# Altium schematic sheet YomraGMPCB.SchDoc (continued)

## Library data of the parts
BZ1 = BUZZER 12mm: Component Kind: Standard; Description: YX-YT12075-YT03D; Library Name: diodes.IntLib
C1 C3-C5 C7 C8 C12 C14 C16 C17 C19-C21 C23 C24 C26 = CAP 1206 100nF 50VDC ±10% X7R: Cap 100nF 50V 1206 SMD 10% X7R; Manufacturer: Yageo; Material: Ceramic; Insulation Resistance: 100GR; Case Code (Metric): 3216; Case Code (Imperial): 1206; Case/Package: 1206; Category: Ceramic Capacitors; Component Kind: Standard; ComponentLink1Description: https://datasheet.ciiva.com/11786/0900766b80d84f1d-11786968.pdf?src-supplier=RSComponents; ComponentLink1URL: https://datasheet.ciiva.com/11786/0900766b80d84f1d-11786968.pdf?src-supplier=RSComponents; ComponentLink2Description: https://datasheet.ciiva.com/7978/1489800-7978230.pdf?src-supplier=Element14; ComponentLink2URL: https://datasheet.ciiva.com/7978/1489800-7978230.pdf?src-supplier=Element14; ComponentLink3Description: https://datasheet.ciiva.com/28450/1489800-28450892.pdf?src-supplier=Element14; ComponentLink3URL: https://datasheet.ciiva.com/28450/1489800-28450892.pdf?src-supplier=Element14; ComponentLink4Description: https://datasheet.ciiva.com/144/mlccorderingcode-144906.pdf?src-supplier=Digi-Key; ComponentLink4URL: https://datasheet.ciiva.com/144/mlccorderingcode-144906.pdf?src-supplier=Digi-Key; ComponentLink5Description: https://datasheet.ciiva.com/144/mlccorderingcode-144905.pdf?src-supplier=Digi-Key; ComponentLink5URL: https://datasheet.ciiva.com/144/mlccorderingcode-144905.pdf?src-supplier=Digi-Key; ComponentLink6Description: https://datasheet.ciiva.com/144/mlccorderingcode-144907.pdf?src-supplier=Digi-Key; ComponentLink6URL: https://datasheet.ciiva.com/144/mlccorderingcode-144907.pdf?src-supplier=Digi-Key; ComponentLink7Description: https://datasheet.ciiva.com/5425/upy-gp-np0-16v-to-50v-8-3714-5425561.pdf?src-supplier=Mouser; ComponentLink7URL: https://datasheet.ciiva.com/5425/upy-gp-np0-16v-to-50v-8-3714-5425561.pdf?src-supplier=Mouser; ComponentLink8Description: https://datasheet.ciiva.com/28363/1734573-28363672.pdf?src-supplier=Element14; ComponentLink8URL: https://datasheet.ciiva.com/28363/1734573-28363672.pdf?src-supplier=Element14; ComponentLink9Description: https://datasheet.ciiva.com/46385/1734573-46385063.pdf?src-supplier=Element14; ComponentLink9URL: https://datasheet.ciiva.com/46385/1734573-46385063.pdf?src-supplier=Element14; ComponentLink10Description: https://datasheet.ciiva.com/15189/getdatasheetpartid-251811-15189275.pdf?src-supplier=Verical; ComponentLink10URL: https://datasheet.ciiva.com/15189/getdatasheetpartid-251811-15189275.pdf?src-supplier=Verical; Depth: 1.6mm; Dielectric: X7R; Halogen Free: Halogen Free; Height: 0.85mm; Lead Free: Lead Free; Length: 3.2mm; Library Name: capacitors.IntLib; Manufacturer Part Number: CC1206KRX7R9BB104; Max Operating Temperature: 125°C; Min Operating Temperature: -55°C; Mount: Surface Mount; Number of Pins: 2; Package Quantity: 1; Packaging: Tape and Reel; Pricing 1: 1=0,19000, 10=0,13500, 50=0,07640, 100=0,06330, 500=0,04436, 1000=0,03672 (USD); Radiation Hardening: No; RoHS: Yes; RoHS Compliant: Yes; Series: CC; Stock 1: 1299936; Supplier 1: Digi-Key; Supplier Part Number 1: 311-1179-1-ND; Termination: SMD/SMT; Thickness: 0.033inch; Tolerance: 10%; Voltage: 50V; Voltage Rating: 50V; Voltage Rating (DC): 50V; Width: 0.063inch
C2 = CAP 1206 330nF 25VDC ±10% X7R: Cap Ceramic 0.33uF 25V X7R 10% SMD 1206 125°C Paper T/R; Manufacturer: Samsung; Case Code (Metric): 3216; Case Code (Imperial): 1206; Case/Package: 1206; Category: Ceramic Capacitors; Component Kind: Standard; ComponentLink1Description: https://datasheet.ciiva.com/25710/cl31b334kacnnnc-25710723.pdf?src-supplier=Digi-Key; ComponentLink1URL: https://datasheet.ciiva.com/25710/cl31b334kacnnnc-25710723.pdf?src-supplier=Digi-Key; ComponentLink2Description: https://datasheet.ciiva.com/26838/getdatasheetpartid-358372-26838950.pdf?src-supplier=Verical; ComponentLink2URL: https://datasheet.ciiva.com/26838/getdatasheetpartid-358372-26838950.pdf?src-supplier=Verical; ComponentLink3Description: https://datasheet.ciiva.com/16202/cl05b104kq5nnnc-16202743.pdf?src-supplier=Future+Electronics; ComponentLink3URL: https://datasheet.ciiva.com/16202/cl05b104kq5nnnc-16202743.pdf?src-supplier=Future+Electronics; Construction: Flat; Depth: 1.6mm; Dielectric: X7R; Height: 0.85mm; Length: 3.2mm; Library Name: capacitors.IntLib; Manufacturer Part Number: CL31B334KACNNNC; Max Operating Temperature: 125°C; Min Operating Temperature: -55°C; Mount: Surface Mount; Packaging: Tape and Reel; Pricing 1: 1=0,22000, 10=0,15200, 50=0,09080, 100=0,07680, 500=0,05252, 1000=0,04646 (USD); Radiation Hardening: No; RoHS: Yes; RoHS Compliant: Yes; Series: CL; Stock 1: 575697; Supplier 1: Digi-Key; Supplier Part Number 1: 1276-1840-1-ND; Thickness: 0.039inch; Tolerance: 10%; Voltage Rating: 25V; Width: 0.063inch
C6 = CAP 1206 2.2nF 50VDC ±10% X7R: CAP CER 2200PF 50V X7R 1206; Manufacturer: Yageo; Dielectric Material: Ceramic; Tolerance: 10%; Insulation Resistance: 100GR; Case Code (Metric): 3216; Case Code (Imperial): 1206; Case/Package: 1206; Category: Ceramic Capacitors; Component Kind: Standard; ComponentLink1Description: https://datasheet.ciiva.com/29148/445097-da-01-en-kerko-chip-1206-x7r-2200pf-10proz-50vg-29148910.pdf?src-supplier=Conrad; ComponentLink1URL: https://datasheet.ciiva.com/29148/445097-da-01-en-kerko-chip-1206-x7r-2200pf-10proz-50vg-29148910.pdf?src-supplier=Conrad; ComponentLink2Description: https://datasheet.ciiva.com/145/mlccorderingcode-145129.pdf?src-supplier=Digi-Key; ComponentLink2URL: https://datasheet.ciiva.com/145/mlccorderingcode-145129.pdf?src-supplier=Digi-Key; ComponentLink3Description: https://datasheet.ciiva.com/145/mlccorderingcode-145128.pdf?src-supplier=Digi-Key; ComponentLink3URL: https://datasheet.ciiva.com/145/mlccorderingcode-145128.pdf?src-supplier=Digi-Key; ComponentLink4Description: https://datasheet.ciiva.com/145/mlccorderingcode-145130.pdf?src-supplier=Digi-Key; ComponentLink4URL: https://datasheet.ciiva.com/145/mlccorderingcode-145130.pdf?src-supplier=Digi-Key; ComponentLink5Description: https://datasheet.ciiva.com/11466/upy-gphc-x7r-6-3v-to-50v-8-11466764.pdf?src-supplier=Avnet; ComponentLink5URL: https://datasheet.ciiva.com/11466/upy-gphc-x7r-6-3v-to-50v-8-11466764.pdf?src-supplier=Avnet; ComponentLink6Description: https://datasheet.ciiva.com/26829/getdatasheetpartid-137961-26829676.pdf?src-supplier=Verical; ComponentLink6URL: https://datasheet.ciiva.com/26829/getdatasheetpartid-137961-26829676.pdf?src-supplier=Verical; Depth: 1.6mm; Dielectric: X7R; Halogen Free: Halogen Free; Height: 0.85mm; Lead Free: Lead Free; Length: 3.2mm; Library Name: capacitors.IntLib; Manufacturer Part Number: CC1206KRX7R9BB222; Material: Ceramic; Max Operating Temperature: 125°C; Min Operating Temperature: -55°C; Mount: Surface Mount; Number of Pins: 2; Package Quantity: 4000; Packaging: Tape and Reel; Pricing 1: 1=0,21000, 10=0,14500, 50=0,08700, 100=0,07350, 500=0,05026, 1000=0,04446 (USD); Radiation Hardening: No; Resistor Type: Ceramic; RoHS: Yes; RoHS Compliant: Yes; Series: CC; Stock 1: 35001; Supplier 1: Digi-Key; Supplier Part Number 1: 311-1171-1-ND; Termination: SMD/SMT; Thickness: 0.033inch; Voltage Rating: 50V; Voltage Rating (DC): 50V; Weight: 0.000571oz; Width: 1.6mm
C9 C27 = CAP 1206 10uF 25VDC ±10% X7R: Ceramic capacitor SMD-1206 10000nF (10uF) /25V X7R# K 10%, Samsung, RoHS/4k/; Dielectric: X7R; Voltage: 25V; Case Code (Metric): 3216; Case Code (Imperial): 1206; Case/Package: 1206; Category: Ceramic Capacitors; Component Kind: Standard; ComponentLink1Description: https://datasheet.ciiva.com/25795/cl31b106kahnnne-25795260.pdf?src-supplier=Digi-Key; ComponentLink1URL: https://datasheet.ciiva.com/25795/cl31b106kahnnne-25795260.pdf?src-supplier=Digi-Key; ComponentLink2Description: https://datasheet.ciiva.com/26838/getdatasheetpartid-356415-26838914.pdf?src-supplier=Verical; ComponentLink2URL: https://datasheet.ciiva.com/26838/getdatasheetpartid-356415-26838914.pdf?src-supplier=Verical; ComponentLink3Description: https://datasheet.ciiva.com/16498/cl03a105mq3csnc-16498474.pdf?src-supplier=Future+Electronics; ComponentLink3URL: https://datasheet.ciiva.com/16498/cl03a105mq3csnc-16498474.pdf?src-supplier=Future+Electronics; Construction: Flat; Depth: 1.6mm; Height: 1.6mm; Length: 3.2mm; Library Name: capacitors.IntLib; Manufacturer: Samsung; Manufacturer Part Number: CL31B106KAHNNNE; Max Operating Temperature: 125°C; Min Operating Temperature: -55°C; Mount: Surface Mount; Package Quantity: 1; Packaging: Tape and Reel; Pricing 1: 1=0,26000, 10=0,17700, 50=0,10640, 100=0,08980, 500=0,06148, 1000=0,05438 (USD); Radiation Hardening: No; RoHS: Yes; RoHS Compliant: Yes; Series: CL; Stock 1: 5845625; Supplier 1: Digi-Key; Supplier Part Number 1: 1276-1804-1-ND; Thickness: 0.071inch; Tolerance: 10%; Voltage Rating: 25V; Width: 0.063inch
C10 C11 = CAP TANTAL 10uF 16V 10% B CASE (3528) SMD: Cap Tant Solid 10uF 16V B CASE 10% (3.5 X 2.8 X 1.9mm) SMD 3528-21 2.8 Ohm 125 C T/R; Manufacturer: KEMET; Dielectric Material: Tantalum; Dissipation Factor: 6%; ESR (Equivalent Series Resistance): 2.8R; Leakage Current: 1.6uA; Life (Hours): 2000Hour; Polarity: Polar; Ripple Current: 174mA; Case Code (Metric): 3528; Case Code (Imperial): 1411; Case/Package: 1411; Category: Tantalum Capacitors; Component Kind: Standard; ComponentLink1Description: https://datasheet.ciiva.com/5934/1776111-5934555.pdf?src-supplier=Farnell; ComponentLink1URL: https://datasheet.ciiva.com/5934/1776111-5934555.pdf?src-supplier=Farnell; ComponentLink2Description: https://datasheet.ciiva.com/27052/1573505-27052566.pdf?src-supplier=Jameco; ComponentLink2URL: https://datasheet.ciiva.com/27052/1573505-27052566.pdf?src-supplier=Jameco; ComponentLink3Description: https://datasheet.ciiva.com/257/kem-t2005-t491-257828.pdf?src-supplier=Digi-Key; ComponentLink3URL: https://datasheet.ciiva.com/257/kem-t2005-t491-257828.pdf?src-supplier=Digi-Key; ComponentLink4Description: https://datasheet.ciiva.com/26341/458322-da-01-en-kondensator-10uf-3528-10pr-16v-85c-26341610.pdf?src-supplier=Conrad; ComponentLink4URL: https://datasheet.ciiva.com/26341/458322-da-01-en-kondensator-10uf-3528-10pr-16v-85c-26341610.pdf?src-supplier=Conrad; ComponentLink5Description: https://datasheet.ciiva.com/7696/kem-t2005-t491-7696439.pdf?src-supplier=Element14; ComponentLink5URL: https://datasheet.ciiva.com/7696/kem-t2005-t491-7696439.pdf?src-supplier=Element14; ComponentLink6Description: https://datasheet.ciiva.com/7696/1854511-7696317.pdf?src-supplier=Element14; ComponentLink6URL: https://datasheet.ciiva.com/7696/1854511-7696317.pdf?src-supplier=Element14; ComponentLink7Description: https://datasheet.ciiva.com/7696/1854511-7696318.pdf?src-supplier=Element14; ComponentLink7URL: https://datasheet.ciiva.com/7696/1854511-7696318.pdf?src-supplier=Element14; ComponentLink8Description: https://datasheet.ciiva.com/7696/1446014-7696319.pdf?src-supplier=Element14; ComponentLink8URL: https://datasheet.ciiva.com/7696/1446014-7696319.pdf?src-supplier=Element14; ComponentLink9Description: https://datasheet.ciiva.com/7696/1854511-7696316.pdf?src-supplier=Element14; ComponentLink9URL: https://datasheet.ciiva.com/7696/1854511-7696316.pdf?src-supplier=Element14; ComponentLink10Description: https://datasheet.ciiva.com/257/kem-t2005-t491-257829.pdf?src-supplier=Digi-Key; ComponentLink10URL: https://datasheet.ciiva.com/257/kem-t2005-t491-257829.pdf?src-supplier=Digi-Key; ComponentLink11Description: https://datasheet.ciiva.com/257/kem-t2005-t491-257830.pdf?src-supplier=Digi-Key; ComponentLink11URL: https://datasheet.ciiva.com/257/kem-t2005-t491-257830.pdf?src-supplier=Digi-Key; ComponentLink12Description: https://datasheet.ciiva.com/39123/kem-t2005-t491-39123279.pdf?src-supplier=Onlinecomponents.com; ComponentLink12URL: https://datasheet.ciiva.com/39123/kem-t2005-t491-39123279.pdf?src-supplier=Onlinecomponents.com; Construction: Flat; Depth: 2.8mm; Features: General Purpose; Height: 1.9mm; Height - Seated (Max): 0.083inch; Lead Free: Lead Free; Lead Pitch: 1.9mm; Length: 3.5mm; Library Name: capacitors.IntLib; Manufacturer Part Number: T491B106K016AT; Max Operating Temperature: 125°C; Min Operating Temperature: -55°C; Mount: Surface Mount,PCB; Number of Pins: 2; Package Quantity: 8000; Packaging: Tape and Reel; Pricing 1: 1=0,54000, 10=0,38300, 50=0,28980, 100=0,25240, 500=0,18698, 1000=0,15894 (USD); Reach SVHC Compliant: Yes; RoHS: Yes; RoHS Compliant: Yes; Series: T491; Size: 1.9mm; Stock 1: 539670; Supplier 1: Digi-Key; Supplier Part Number 1: 399-3706-1-ND; Termination: SMD/SMT; Tolerance: 10%; Voltage: 16V; Voltage Rating: 16V; Voltage Rating (DC): 16V; Width: 2.8mm
C13 = DSK-3R3H703T414-HRL: Cap 70MF -20% +80% 3.3V SMD; Manufacturer: Elna; ESR (Equivalent Series Resistance): 100R; Life (Hours): 500Hour; Tolerance: 80%; Case/Package: SMD/SMT; Category: Uncategorized; Component Kind: Standard; ComponentLink1Description: https://datasheet.ciiva.com/238/dsk414-e-238214.pdf?src-supplier=Digi-Key; ComponentLink1URL: https://datasheet.ciiva.com/238/dsk414-e-238214.pdf?src-supplier=Digi-Key; ComponentLink2Description: https://datasheet.ciiva.com/238/dsk414-e-238215.pdf?src-supplier=Digi-Key; ComponentLink2URL: https://datasheet.ciiva.com/238/dsk414-e-238215.pdf?src-supplier=Digi-Key; ComponentLink3Description: https://datasheet.ciiva.com/238/dsk414-e-238216.pdf?src-supplier=Digi-Key; ComponentLink3URL: https://datasheet.ciiva.com/238/dsk414-e-238216.pdf?src-supplier=Digi-Key; Height - Seated (Max): 0.085inch; Library Name: capacitors.IntLib; Manufacturer Part Number: DSK-3R3H703T414-HRL; Max Operating Temperature: 70°C; Min Operating Temperature: -10°C; Mount: Surface Mount; Package Quantity: 1; Packaging: Tape and Reel; Pricing 1: 1=1,30000, 10=1,02700, 50=0,89020, 100=0,77040, 500=0,58206, 1000=0,51358 (USD); RoHS: Yes; RoHS Compliant: Yes; Series: DSK; Stock 1: 43882; Supplier 1: Digi-Key; Supplier Part Number 1: 604-1148-1-ND; Termination: SMD/SMT
C15 = CAP FEEDTHRU 47PF 100V 1206 W3F11A4708AT1F: Capacitor, Ceramic; 47 pF; 100 V; 300 mA; %, -20%; 1206; NP0; lt0.6 Ohms; Manufacturer: Kyocera AVX; Current: 300mA; DC Resistance (DCR): 600mR; Dielectric: C0G; Tolerance: 50%; Voltage: 100V; Insulation Resistance: 1GR; Case Code (Metric): 3216; Case Code (Imperial): 1206; Case/Package: 1206; Category: Ceramic Capacitors; Component Kind: Standard; ComponentLink1Description: https://datasheet.ciiva.com/4932/w2fw3f-4932037.pdf?src-supplier=Mouser; ComponentLink1URL: https://datasheet.ciiva.com/4932/w2fw3f-4932037.pdf?src-supplier=Mouser; ComponentLink2Description: https://datasheet.ciiva.com/6213/w2fw3f-6213557.pdf?src-supplier=Digi-Key; ComponentLink2URL: https://datasheet.ciiva.com/6213/w2fw3f-6213557.pdf?src-supplier=Digi-Key; ComponentLink3Description: https://datasheet.ciiva.com/11567/w2fw3f-11567749.pdf?src-supplier=Avnet; ComponentLink3URL: https://datasheet.ciiva.com/11567/w2fw3f-11567749.pdf?src-supplier=Avnet; Construction: Flat; Current Rating: 300mA; Depth: 1.6mm; Dielectric Material: Ceramic; Height: 1.27mm; Lead Free: Lead Free; Length: 3.2mm; Library Name: capacitors.IntLib; Manufacturer Part Number: W3F11A4708AT1F; Max Operating Temperature: 125°C; Min Operating Temperature: -55°C; Mount: Surface Mount; Number of Pins: 4; Package Quantity: 1000; Packaging: Tape and Reel; Pricing 1: 1=0,59000, 10=0,41400, 50=0,31320, 100=0,27270, 500=0,20200 (USD); Radiation Hardening: No; Ratings: AEC-Q200; Reach SVHC Compliant: Yes; RoHS: Yes; RoHS Compliant: Yes; Series: W3F; Stock 1: 1380; Supplier 1: Digi-Key; Supplier Part Number 1: 478-2238-1-ND; Termination: SMD/SMT; Voltage Rating: 100V; Voltage Rating (DC): 100V; Width: 1.6mm
C18 = CAP 1206 22uF 10VDC ±10% X7R: Cap Ceramic 22uF 10V X7R 10% SMD 1206 125C Embossed Plastic T/R; Manufacturer: Samsung; Case Code (Metric): 3216; Case Code (Imperial): 1206; Case/Package: 1206; Category: Ceramic Capacitors; Component Kind: Standard; ComponentLink1Description: https://datasheet.ciiva.com/25724/cl31b226kphnnne-25724443.pdf?src-supplier=Digi-Key; ComponentLink1URL: https://datasheet.ciiva.com/25724/cl31b226kphnnne-25724443.pdf?src-supplier=Digi-Key; ComponentLink2Description: https://datasheet.ciiva.com/16384/cl31b226kphnnne-16384588.pdf?src-supplier=Future+Electronics; ComponentLink2URL: https://datasheet.ciiva.com/16384/cl31b226kphnnne-16384588.pdf?src-supplier=Future+Electronics; ComponentLink3Description: https://datasheet.ciiva.com/26838/getdatasheetpartid-710483-26838941.pdf?src-supplier=Verical; ComponentLink3URL: https://datasheet.ciiva.com/26838/getdatasheetpartid-710483-26838941.pdf?src-supplier=Verical; Contact Plating: Tin; Depth: 1.6mm; Dielectric: X7R; Lead Free: Lead Free; Length: 3.2mm; Library Name: capacitors.IntLib; Manufacturer Part Number: CL31B226KPHNNNE; Max Operating Temperature: 125°C; Min Operating Temperature: -55°C; Mount: Surface Mount; Package Quantity: 2000; Packaging: Tape and Reel; Pricing 1: 1=0,42000, 10=0,28900, 50=0,20240, 100=0,17360, 500=0,13016, 1000=0,10992 (USD); Radiation Hardening: No; RoHS: Yes; RoHS Compliant: Yes; Stock 1: 1595180; Supplier 1: Digi-Key; Supplier Part Number 1: 1276-2769-1-ND; Termination: Wraparound; Thickness: 0.071inch; Tolerance: 10%; Voltage Rating: 10V; Voltage Rating (DC): 10V; Width: 0.063inch
C22 = CAP 1206 47pF 25VDC ±5%: Cap, 47PF, 25V, 5%, C0G/NP0, 1206; Manufacturer: Wurth Electronics; Case Code (Metric): 3216; Case Code (Imperial): 1206; Case/Package: 1206; Category: Ceramic Capacitors; Component Kind: Standard; ComponentLink1Description: http://www.farnell.com/datasheets/2546684.pdf?src-supplier=Farnell; ComponentLink1URL: http://www.farnell.com/datasheets/2546684.pdf?src-supplier=Farnell; ComponentLink2Description: https://katalog.we-online.de/pbs/datasheet/885012008021.pdf?src-supplier=Digi-Key; ComponentLink2URL: https://katalog.we-online.de/pbs/datasheet/885012008021.pdf?src-supplier=Digi-Key; Length: 0.126inch; Library Name: capacitors.IntLib; Manufacturer Part Number: 885012008021; Max Operating Temperature: 125°C; Min Operating Temperature: -55°C; Mount: Surface Mount; Packaging: Tape and Reel; Pricing 1: 1=0,11000, 10=0,10300, 100=0,09300, 500=0,08200 (USD); RoHS: Yes; RoHS Compliant: Yes; Stock 1: 12089; Supplier 1: Digi-Key; Supplier Part Number 1: 732-7874-1-ND; Thickness: 0.035inch; Tolerance: 5%; Voltage Rating: 25V; Width: 0.063inch
C25 = CAP 1206 4.7uF 10VDC ±10% X7R: 1206 C 4.7uF Ceramic Multilayer Capacitor; 10 VDC; +125degC; X7R Dielec; +/-10%; Manufacturer: KEMET; Dissipation Factor: 5%; Tolerance: 10%; Voltage: 10V; Case Code (Metric): 3216; Case Code (Imperial): 1206; Case/Package: 1206; Category: Ceramic Capacitors; Component Kind: Standard; ComponentLink1Description: https://datasheet.ciiva.com/5412/kem-c1002-x7r-smd-357932-5412261.pdf?src-supplier=Mouser; ComponentLink1URL: https://datasheet.ciiva.com/5412/kem-c1002-x7r-smd-357932-5412261.pdf?src-supplier=Mouser; ComponentLink2Description: https://datasheet.ciiva.com/14604/70095383-14604677.pdf?src-supplier=Allied+Electronics; ComponentLink2URL: https://datasheet.ciiva.com/14604/70095383-14604677.pdf?src-supplier=Allied+Electronics; ComponentLink3Description: https://datasheet.ciiva.com/22752/1851736-22752187.pdf?src-supplier=Element14; ComponentLink3URL: https://datasheet.ciiva.com/22752/1851736-22752187.pdf?src-supplier=Element14; Construction: Flat; Depth: 1.6mm; Dielectric: X7R; Dielectric Material: Ceramic; Height: 1.2mm; Length: 3.2mm; Library Name: capacitors.IntLib; Manufacturer Part Number: C1206C475K8RACTU; Max Operating Temperature: 125°C; Min Operating Temperature: -55°C; Mount: Surface Mount,PCB; Package Quantity: 1; Packaging: Tape and Reel; Pricing 1: 1=0,40000, 10=0,27800, 50=0,19440, 100=0,16660, 500=0,12498, 1000=0,10554 (USD); Radiation Hardening: No; Reach SVHC Compliant: Yes; RoHS: Yes; RoHS Compliant: Yes; Series: C; Stock 1: 18898; Supplier 1: Digi-Key; Supplier Part Number 1: 399-C1206C475K8RAC7800CT-ND; Termination: SMD/SMT; Thickness: 0.071inch; Voltage Rating: 10V; Voltage Rating (DC): 10V; Width: 0.063inch
D1 = SS1030_R1_00001: Diode Schottky 30V 1A 2-Pin SOD-123 T/R; Manufacturer: Pan Jit; Element Configuration: Single; Max Reverse Current: 50uA; Peak Non-Repetitive Surge Current: 30A; Category: Rectifier Diodes; Component Kind: Standard; ComponentLink1Description: http://www.panjit.com/upload/product/5/SS1030_SERIES%20140902%20REV.03.pdf?src-supplier=Avnet; ComponentLink1URL: http://www.panjit.com/upload/product/5/SS1030_SERIES%20140902%20REV.03.pdf?src-supplier=Avnet; Forward Current: 1A; Library Name: diodes.IntLib; Manufacturer Part Number: SS1030_R1_00001; Max Operating Temperature: 125°C; Max Repetitive Reverse Voltage (Vrrm): 30V; Min Operating Temperature: -40°C; Mount: Surface Mount; Pricing 1: 1=0,33000, 10=0,26900, 100=0,14270, 500=0,09390, 1000=0,06385 (USD); Radiation Hardening: No; RoHS: Yes; RoHS Compliant: Yes; Stock 1: 7088; Supplier 1: Digi-Key; Supplier Part Number 1: 3757-SS1030_R1_00001DKR-ND
D2 = 1N4148WS-HT: DIODE FAST SWITCHING Single 150mA 75V SMT SOD323; Component Kind: Standard; Library Name: diodes.IntLib
D3 = GREEN LED 1206: LED SMD 1206 GREEN 600mcd 120° WATER CLEAR; Component Kind: Standard; Library Name: diodes.IntLib
J1 = SMA CONNECTOR: Rf Coax Conn, Sma Jack, 50 Ohm, Pcb Rohs Compliant: Yes; Manufacturer: Wurth Electronics; Manufacturer Part Number: 60312002114503; Category: RF / Coaxial Connectors; Component Kind: Standard; ComponentLink1Description: https://katalog.we-online.de/em/datasheet/60312002114503.pdf?src-supplier=Digi-Key; ComponentLink1URL: https://katalog.we-online.de/em/datasheet/60312002114503.pdf?src-supplier=Digi-Key; Pricing 1: 1=5,76000, 50=5,54000, 100=5,31900, 180=4,87600, 360=4,43300 (USD); RoHS: Yes; RoHS Compliant: Yes; Stock 1: 2603; Supplier 1: Digi-Key; Supplier Part Number 1: 732-13835-ND; Library Name: cons.IntLib
J2 = SD Card Connector: 1.10mm Pitch microSD Memory Card Connector, Reverse Mount Surface Mount, Push-Push Type; Manufacturer: Molex; Current Rating: 500mA; Gender: Male; Max Voltage Rating (DC): 1.01kV; Number of Circuits: 8; Pitch: 1.1mm; Voltage Rating (AC): 10V; Category: Uncategorized; Component Kind: Standard; ComponentLink1Description: https://datasheet.ciiva.com/15226/getdatasheetpartid-119109-15226226.pdf?src-supplier=Verical; ComponentLink1URL: https://datasheet.ciiva.com/15226/getdatasheetpartid-119109-15226226.pdf?src-supplier=Verical; ComponentLink2Description: https://datasheet.ciiva.com/11755/0900766b80f752a0-11755064.pdf?src-supplier=RSComponents; ComponentLink2URL: https://datasheet.ciiva.com/11755/0900766b80f752a0-11755064.pdf?src-supplier=RSComponents; ComponentLink3Description: https://datasheet.ciiva.com/4581/5027740891-memory-card-socket-179575-4581102.pdf?src-supplier=Mouser; ComponentLink3URL: https://datasheet.ciiva.com/4581/5027740891-memory-card-socket-179575-4581102.pdf?src-supplier=Mouser; ComponentLink4Description: https://datasheet.ciiva.com/683/5027740891-sd-683628.pdf?src-supplier=Digi-Key; ComponentLink4URL: https://datasheet.ciiva.com/683/5027740891-sd-683628.pdf?src-supplier=Digi-Key; ComponentLink5Description: https://datasheet.ciiva.com/1104/ps-502774-001-1104670.pdf?src-supplier=Element14; ComponentLink5URL: https://datasheet.ciiva.com/1104/ps-502774-001-1104670.pdf?src-supplier=Element14; ComponentLink6Description: https://datasheet.ciiva.com/1104/1499551-1104671.pdf?src-supplier=Element14; ComponentLink6URL: https://datasheet.ciiva.com/1104/1499551-1104671.pdf?src-supplier=Element14; ComponentLink7Description: https://datasheet.ciiva.com/16655/5027740891-memory-card-socket-16655603.pdf?; ComponentLink7URL: https://datasheet.ciiva.com/16655/5027740891-memory-card-socket-16655603.pdf?; ComponentLink8Description: https://datasheet.ciiva.com/683/5027740891-sd-683629.pdf?src-supplier=Digi-Key; ComponentLink8URL: https://datasheet.ciiva.com/683/5027740891-sd-683629.pdf?src-supplier=Digi-Key; ComponentLink9Description: https://datasheet.ciiva.com/683/5027740891-sd-683630.pdf?src-supplier=Digi-Key; ComponentLink9URL: https://datasheet.ciiva.com/683/5027740891-sd-683630.pdf?src-supplier=Digi-Key; Connector Type: Connector; Contact Material: Copper Alloy,Copper; Contact Plating: Gold; Depth: 16mm; Halogen Free: Low Halogen; Height: 1.8mm; Housing Material: Polymer; Length: 14.6mm; Manufacturer Part Number: 5027740891; Max Operating Temperature: 85°C; Min Operating Temperature: -25°C; Mount: Surface Mount; Number of Contacts: 8; Number of Rows: 1; Orientation: Right Angle; Package Quantity: 1; Packaging: Tape and Reel; Pricing 1: 1=3,87000, 10=3,40500, 100=2,70830 (USD); Radiation Hardening: No; RoHS: Yes; Series: microSD Card; Stock 1: 15870; Supplier 1: Digi-Key; Supplier Part Number 1: WM24066CT-ND; Termination: Solder; Width: 1.8mm; Library Name: cons.IntLib
J9 = u.FL CONNECTOR: Surface Mount Ultra Miniature Coaxial Jack Connector; Manufacturer: Linx; Manufacturer Part Number: CONUFL001-SMD-T; Body Material: Copper Alloy; Body Plating: Gold; Connector Type: Male Pin; Dielectric Material: Polymer; Max Frequency: 6GHz; Number of Ports: 1; Impedance: 50R; Category: RF / Coaxial Connectors; Component Kind: Standard; ComponentLink1Description: https://media.digikey.com/pdf/Data%20Sheets/Linx%20Technologies%20PDFs/CONUFL001-SMD_T_Dwg_RevB_5-15-17.pdf?src-supplier=Digi-Key; ComponentLink1URL: https://media.digikey.com/pdf/Data%20Sheets/Linx%20Technologies%20PDFs/CONUFL001-SMD_T_Dwg_RevB_5-15-17.pdf?src-supplier=Digi-Key; Contact Material: Brass; Contact Plating: Gold; Fastening Type: Snap; Housing Color: White; Max Operating Temperature: 90°C; Min Operating Temperature: -40°C; Mount: Surface Mount; Packaging: Tape and Reel; Pricing 1: 1=0,71000, 10=0,68000, 25=0,66000, 100=0,61000, 250=0,58000, 500=0,55000, 1000=0,50000 (USD); RoHS: Yes; RoHS Compliant: No; Stock 1: 169571; Supplier 1: Digi-Key; Supplier Part Number 1: CONUFL001-SMD-TCT-ND; Termination: Solder; Library Name: cons.IntLib
L1 = FIXED IND 27NH 350MA 460MOHM SMD: Inductor RF Chip Multi-Layer 27nH 5% 100MHz 8Q-Factor Air 300mA 460mOhm DCR 0402 Paper T/R; Manufacturer: Murata; DC Resistance (DCR): 460mR; Q Factor: 8; Self Resonant Frequency: 1.7GHz; Shielding: Unshielded; Case Code (Metric): 1005; Case Code (Imperial): 0402; Case/Package: 0402; Category: Fixed Inductors; Component Kind: Standard; ComponentLink1Description: https://datasheet.ciiva.com/11799/0900766b80f10809-11799242.pdf?src-supplier=RSComponents; ComponentLink1URL: https://datasheet.ciiva.com/11799/0900766b80f10809-11799242.pdf?src-supplier=RSComponents; ComponentLink2Description: https://datasheet.ciiva.com/1038/l0075s0083lqg15hs-1038146.pdf?src-supplier=Digi-Key; ComponentLink2URL: https://datasheet.ciiva.com/1038/l0075s0083lqg15hs-1038146.pdf?src-supplier=Digi-Key; ComponentLink3Description: https://datasheet.ciiva.com/1038/l0075s0083lqg15hs-1038145.pdf?src-supplier=Digi-Key; ComponentLink3URL: https://datasheet.ciiva.com/1038/l0075s0083lqg15hs-1038145.pdf?src-supplier=Digi-Key; ComponentLink4Description: https://datasheet.ciiva.com/1038/l0075s0083lqg15hs-1038147.pdf?src-supplier=Digi-Key; ComponentLink4URL: https://datasheet.ciiva.com/1038/l0075s0083lqg15hs-1038147.pdf?src-supplier=Digi-Key; ComponentLink5Description: https://datasheet.ciiva.com/3082/l0075s0083lqg15hs-3082179.pdf?src-supplier=Avnet; ComponentLink5URL: https://datasheet.ciiva.com/3082/l0075s0083lqg15hs-3082179.pdf?src-supplier=Avnet; ComponentLink6Description: https://datasheet.ciiva.com/16360/l0075s0083lqg15hs-16360143.pdf?src-supplier=Future+Electronics; ComponentLink6URL: https://datasheet.ciiva.com/16360/l0075s0083lqg15hs-16360143.pdf?src-supplier=Future+Electronics; Core Material: Air; Current Rating: 300mA; DC Current: 300mA; Depth: 0.55mm; Height: 0.5mm; Height - Seated (Max): 0.022inch; Lead Free: Lead Free; Length: 1mm; Manufacturer Part Number: LQG15HS27NJ02D; Max DC Current: 300mA; Max Operating Temperature: 125°C; Military Standard: Not; Min Operating Temperature: -55°C; Mount: Surface Mount; Package Quantity: 1; Packaging: Tape and Reel; Pricing 1: 1=0,10000, 10=0,05300, 25=0,04720, 50=0,04020, 100=0,03550, 250=0,03248, 500=0,02954, 1000=0,02482, 2500=0,02127 (USD); Rated Current-Max: 300mA; Reach SVHC Compliant: Yes; RoHS: Yes; RoHS Compliant: Yes; Series: LQG15HS; Stock 1: 813124; Supplier 1: Digi-Key; Supplier Part Number 1: 490-2628-1-ND; Termination: SMD/SMT; Test Frequency: 100MHz; Tolerance: 5%; Width: 0.02inch; Inductance Tolerance: 5%; Library Name: ınductors.IntLib
L2 = FIXED IND 0603 6.8nH SMD: Inductance: 6.8nH; Component Kind: Standard; Library Name: ınductors.IntLib
Q1 Q2 = SS8050-G: Trans Gp Bjt NPN 25V 1.5A 300MW 3-PIN SOT-23 T/r; Manufacturer: Comchip; Collector Emitter Voltage (VCEO): 400mV; Transition Frequency: 100MHz; Case/Package: TO-236-3; Category: Transistors; Collector Emitter Breakdown Voltage: 25V; Component Kind: Standard; ComponentLink1Description: http://www.comchiptech.com/admin/files/product/SS8050-G RevA181526.pdf?src-supplier=Digi-Key; ComponentLink1URL: http://www.comchiptech.com/admin/files/product/SS8050-G RevA181526.pdf?src-supplier=Digi-Key; ComponentLink2Description: http://www.comchiptech.com/cms/UserFiles/SS8050-G%20RevA181526.pdf?src-supplier=Digi-Key; ComponentLink2URL: http://www.comchiptech.com/cms/UserFiles/SS8050-G%20RevA181526.pdf?src-supplier=Digi-Key; Library Name: transistor.IntLib; Manufacturer Part Number: SS8050-G; Max Breakdown Voltage: 25V; Max Collector Current: 1.5A; Max Power Dissipation: 300mW; Mount: Surface Mount; Packaging: Tape and Reel; Pricing 1: 1=0,29000, 10=0,23600, 100=0,12540, 500=0,08250, 1000=0,05610 (USD); RoHS: Yes; Stock 1: 406507; Supplier 1: Digi-Key; Supplier Part Number 1: 641-1790-1-ND
R1 R2 R14 = RES 1206 4.7K 1% 1/4W: MULTICOMP - MC1206S4F4701T5E - RES, THICK FILM, 4K7, 1%, 0.25W, 1206; Power Rating: 250mW; Temperature Coefficient: 100ppm/°C; Voltage Rating: 200V; Case Code (Metric): 3216; Case Code (Imperial): 1206; Category: Resistors; Component Kind: Standard; ComponentLink1Description: https://datasheet.ciiva.com/7918/1509465-7918830.pdf?src-supplier=Element14; ComponentLink1URL: https://datasheet.ciiva.com/7918/1509465-7918830.pdf?src-supplier=Element14; ComponentLink2Description: https://datasheet.ciiva.com/28673/1509465-28673502.pdf?src-supplier=Element14; ComponentLink2URL: https://datasheet.ciiva.com/28673/1509465-28673502.pdf?src-supplier=Element14; Library Name: resistors.IntLib; Manufacturer: Multicomp; Manufacturer Part Number: MC1206S4F4701T5E; Number of Pins: 2; Packaging: Tape and Reel; Pricing 1: 5000=0,00960, 10000=0,00820, 25000=0,00690, 50000=0,00580 (EUR); Resistance Tolerance: 1%; Resistor Type: Thick Film; RoHS: Yes; Stock 1: 5000; Supplier 1: Farnell; Supplier Part Number 1: 2371706; Tolerance: 1%; Voltage Rating (DC): 200V
R3-R6 R16 R20 R21 R24 = RES 1206 10K %5 1/4W: Res Thick Film 1206 10K Ohm 5% 0.25W(1/4W) ±100ppm/°C Pad SMD T/R; Manufacturer: Royal Electronic Factory; Component Kind: Standard; Library Name: resistors.IntLib; Manufacturer Part Number: 1206S4J0103T5E; Pricing 1: 10000=0,00200 (USD); RoHS: Yes; RoHS Compliant: Yes; Supplier 1: Mouser; Supplier Part Number 1: 303-1206S4J0103T5E
R7 = RES 1206 5.6K 1% 1/4W: Thick Film SMD Chip Resistor; Manufacturer: Royal Electronic Factory; Component Kind: Standard; Library Name: resistors.IntLib; Manufacturer Part Number: 1206S4F5601T5E; Pricing 1: 10000=0,00300 (USD); RoHS: Yes; Supplier 1: Mouser; Supplier Part Number 1: 303-1206S4F5601T5E
R8 = RES 1206 3.3K 1% 1/4W: Manufacturer: Royal Electronic Factory; Tolerance: 1%; Category: Resistors; Component Kind: Standard; Library Name: resistors.IntLib; Manufacturer Part Number: 1206S4F3301T5E; Pricing 1: 10000=0,00300 (USD); RoHS: Yes; RoHS Compliant: Yes; Supplier 1: Mouser; Supplier Part Number 1: 303-1206S4F3301T5E
R9 R10 = RES 1206 1K 5% 1/4W: Res Thick Film 1206 1K Ohm 5% 0.25W(1/4W) ±100ppm/°C Pad SMD T/R; Manufacturer: Royal Electronic Factory; Component Kind: Standard; Library Name: resistors.IntLib; Manufacturer Part Number: 1206S4J0102T5E; Pricing 1: 10000=0,00200 (USD); RoHS: Yes; RoHS Compliant: Yes; Supplier 1: Mouser; Supplier Part Number 1: 303-1206S4J0102T5E
R11 = RES 1206 510 Ohms 5% 1/4W-S 100PPM: Manufacturer: Royal Electronic Factory; Resistance: 510R; Component Kind: Standard; Library Name: resistors.IntLib; Manufacturer Part Number: 1206S4J0511T5E; Pricing 1: 10000=0,00200 (USD); RoHS: Yes; RoHS Compliant: Yes; Supplier 1: Mouser; Supplier Part Number 1: 303-1206S4J0511T5E
R12 R13 = RES 1206 510 Ohms 5% 1/4W-S 100PPM: Manufacturer: Royal Electronic Factory; Component Kind: Standard; Library Name: resistors.IntLib; Manufacturer Part Number: 1206S4J0511T5E; Pricing 1: 10000=0,00200 (USD); RoHS: Yes; RoHS Compliant: Yes; Supplier 1: Mouser; Supplier Part Number 1: 303-1206S4J0511T5E
R15 = RES 1206 10 Ohms 5% 1/4W: Manufacturer: Royal Electronic Factory; Component Kind: Standard; Library Name: resistors.IntLib; Manufacturer Part Number: 1206S4J0100T5E; Pricing 1: 10000=0,00200 (USD); RoHS: Yes; RoHS Compliant: Yes; Supplier 1: Mouser; Supplier Part Number 1: 303-1206S4J0100T5E
R17 = RES 1206 22.1K 1% 1/4W: RES THICK FILM, 22.1KOHM, 125mW, 1%; Manufacturer: Multicomp; Manufacturer Part Number: MC1206S4F2212T5E; Power Rating: 250mW; Temperature Coefficient: 100ppm/°C; Voltage Rating: 200V; Case Code (Metric): 3216; Case Code (Imperial): 1206; Case/Package: 1206; Category: Resistors; Component Kind: Standard; ComponentLink1Description: https://datasheet.ciiva.com/7887/ta-677-7887767.pdf?src-supplier=Element14; ComponentLink1URL: https://datasheet.ciiva.com/7887/ta-677-7887767.pdf?src-supplier=Element14; Library Name: resistors.IntLib; Number of Pins: 2; Packaging: Cut Tape; Pricing 1: 1=0,12100, 10=0,04400, 100=0,03400, 1000=0,01200, 5000=0,01200 (USD); Resistance Tolerance: 1%; Resistor Type: Thick Film; RoHS: Yes; Supplier 1: Newark; Supplier Part Number 1: 04P0794; Tolerance: 1%; Voltage Rating (DC): 200V
R18 = RES 1206 2K 5% 1/4W: Manufacturer: Royal Electronic Factory; Category: Uncategorized; Component Kind: Standard; Library Name: resistors.IntLib; Manufacturer Part Number: CQ06S4J0202T5E; Pricing 1: 10000=0,00300 (USD); RoHS: No; Supplier 1: Mouser; Supplier Part Number 1: 303-CQ06S4J0202T5E
R19 = RES 1206 47.5K 1% 1/4W: Manufacturer: Royal Electronic Factory; Component Kind: Standard; Library Name: resistors.IntLib; Manufacturer Part Number: 1206S4F4752T5E; Pricing 1: 10000=0,00300 (USD); Pricing 2: 10000=0,00300 (USD); RoHS: Yes; RoHS Compliant: Yes; Supplier 1: Mouser; Supplier 2: Mouser; Supplier Part Number 2: 303-1206S4F4752T5E
R22 R23 = RES 1206 0R 5% 1/4W: Thick Film Chip Resistors; Manufacturer: Royal Electronic Factory; Component Kind: Standard; Library Name: resistors.IntLib; Manufacturer Part Number: 1206S4J0000T5E; Pricing 1: 10000=0,00200 (USD); RoHS: Yes; RoHS Compliant: Yes; Supplier 1: Mouser; Supplier Part Number 1: 303-1206S4J0000T5E
U1 = L7805CDT-TR 5V 1.5A DPAK TO252: IC REG LDO 5V 1.5A DPAK; Manufacturer: STMicroelectronics; Dropout Voltage: 2V; Max Input Voltage: 35V; Min Input Voltage: 7V; Number of Outputs: 1; Output Type: Fixed; Polarity: Positive; Power Supply Rejection Ratio (PSRR): 62dB; Quiescent Current: 8mA; Case/Package: TO-252; Category: Voltage Regulators - Linear; Component Kind: Standard; ComponentLink1Description: https://datasheet.ciiva.com/2042/cd00000444-2042784.pdf?src-supplier=Digi-Key; ComponentLink1URL: https://datasheet.ciiva.com/2042/cd00000444-2042784.pdf?src-supplier=Digi-Key; ComponentLink2Description: https://datasheet.ciiva.com/2042/cd00000444-2042783.pdf?src-supplier=Digi-Key; ComponentLink2URL: https://datasheet.ciiva.com/2042/cd00000444-2042783.pdf?src-supplier=Digi-Key; ComponentLink3Description: https://datasheet.ciiva.com/2042/cd00000444-2042785.pdf?src-supplier=Digi-Key; ComponentLink3URL: https://datasheet.ciiva.com/2042/cd00000444-2042785.pdf?src-supplier=Digi-Key; ComponentLink4Description: https://datasheet.ciiva.com/4882/cd00000444-4882231.pdf?src-supplier=Mouser; ComponentLink4URL: https://datasheet.ciiva.com/4882/cd00000444-4882231.pdf?src-supplier=Mouser; ComponentLink5Description: https://datasheet.ciiva.com/16357/cd00000444-16357893.pdf?src-supplier=Future+Electronics; ComponentLink5URL: https://datasheet.ciiva.com/16357/cd00000444-16357893.pdf?src-supplier=Future+Electronics; Contact Plating: Tin,Matte; Height: 2.4mm; Lead Free: Lead Free; Length: 6.6mm; Library Name: ic-power.IntLib; Manufacturer Part Number: L7805CDT-TR; Max Operating Temperature: 125°C; Max Output Current: 1.5A; Min Operating Temperature: 0°C; Min Output Voltage: 5V; Mount: Surface Mount; Number of Pins: 3; Number of Regulators: 1; Output Current: 1.5A; Output Voltage: 5V; Package Quantity: 1; Packaging: Tape and Reel; Pricing 1: 1=1,12000, 10=0,99900, 25=0,94800, 100=0,77870, 250=0,72792, 500=0,64328, 1000=0,55895 (USD); Radiation Hardening: No; RoHS: Yes; RoHS Compliant: Yes; Series: -; Stock 1: 53520; Supplier 1: Digi-Key; Supplier Part Number 1: 497-7255-1-ND; Width: 6.2mm
U2 = MPU-6050: IMU ACCEL/GYRO 3-AXIS I2C 24QFN; Manufacturer: TDK InvenSense; Sensor Type: Accelerometer,Gyroscope,6 Axis; Case/Package: QFN; Category: Inertial Measurement Units (IMUs); Component Kind: Standard; ComponentLink1Description: https://datasheet.ciiva.com/1513/1788002-1513632.pdf?src-supplier=Element14; ComponentLink1URL: https://datasheet.ciiva.com/1513/1788002-1513632.pdf?src-supplier=Element14; ComponentLink2Description: https://datasheet.ciiva.com/22731/1788002-22731434.pdf?src-supplier=Element14; ComponentLink2URL: https://datasheet.ciiva.com/22731/1788002-22731434.pdf?src-supplier=Element14; Library Name: ic-sensor.IntLib; Manufacturer Part Number: MPU-6050; Max Operating Temperature: 85°C; Min Operating Temperature: -40°C; Mount: Surface Mount; Output Type: I2C; Packaging: Cut Tape; Pricing 1: 1=9,11000, 5=7,99200, 10=7,19300, 25=6,39400, 50=5,91440, 100=5,11520, 500=4,79550, 1000=4,47580 (USD); RoHS: Yes; RoHS Compliant: Yes; Series: -; Stock 1: 781; Supplier 1: Digi-Key; Supplier Part Number 1: 1428-1007-1-ND
U3 = MS561101BA03-50: Pressure Sensor 1.44V to 3.6V 0.01bar to 1.2bar Absolute 8-Pin QFN T/R; Manufacturer: TE Connectivity; Category: Pressure Sensors; Component Kind: Standard; ComponentLink1Description: http://www.farnell.com/datasheets/2917208.pdf?src-supplier=Farnell; ComponentLink1URL: http://www.farnell.com/datasheets/2917208.pdf?src-supplier=Farnell; ComponentLink2Description: https://www.te.com/commerce/DocumentDelivery/DDEController?Action=srchrtrv&DocNm=MS5611-01BA03&DocType=Data+Sheet&DocLang=English&src-supplier=Digi-Key; ComponentLink2URL: https://www.te.com/commerce/DocumentDelivery/DDEController?Action=srchrtrv&DocNm=MS5611-01BA03&DocType=Data+Sheet&DocLang=English&src-supplier=Digi-Key; Library Name: ic-sensor.IntLib; Manufacturer Part Number: MS561101BA03-50; Pricing 1: 1=12,90000, 5=11,31200, 10=10,18100, 25=9,04960, 50=8,37080, 100=7,23970, 500=6,78720, 1000=6,33472 (USD); RoHS: Yes; Stock 1: 4737; Supplier 1: Digi-Key; Supplier Part Number 1: 223-1622-1-ND
U4 = E19-433M20S2: SX1278 433MHz 100mW SMD Wireless Module; Current - Receiving: 14mA; Current - Transmitting: 128mA; Data Rate: 300Kbps; Frequency: 410-525MHz; Sensitivity: -147dBm; Interface: SPI; Case/Package: Module; Category: RF Receivers, Transceivers; Component Kind: Standard; ComponentLink1Description: https://github.com/SeeedDocument/RFM95-98_LoRa_Module/blob/master/RFM95_96_97_98_DataSheet.pdf?src-supplier=Digi-Key; ComponentLink1URL: https://github.com/SeeedDocument/RFM95-98_LoRa_Module/blob/master/RFM95_96_97_98_DataSheet.pdf?src-supplier=Digi-Key; Library Name: ic-sensor.IntLib; Max Operating Temperature: 85°C; Min Operating Temperature: -40°C; Mount: Surface Mount
U5 = LD1086DT33TR 3.3V 1.5A DPAK TO252: IC REG LDO 3.3V 1.5A DPAK; Manufacturer: STMicroelectronics; Accuracy: 1%; Dropout Voltage: 1.3V; Max Input Voltage: 30V; Min Input Voltage: 6.3V; Number of Outputs: 1; Output Type: Fixed; Polarity: Positive; Quiescent Current: 10mA; Input Bias Current: 5mA; Case/Package: TO-252; Category: Voltage Regulators - Linear; Component Kind: Standard; ComponentLink1Description: https://datasheet.ciiva.com/1511/1880971-1511550.pdf?src-supplier=Element14; ComponentLink1URL: https://datasheet.ciiva.com/1511/1880971-1511550.pdf?src-supplier=Element14; ComponentLink2Description: https://datasheet.ciiva.com/1511/1880971-1511549.pdf?src-supplier=Element14; ComponentLink2URL: https://datasheet.ciiva.com/1511/1880971-1511549.pdf?src-supplier=Element14; ComponentLink3Description: https://datasheet.ciiva.com/2043/cd00001884-2043961.pdf?src-supplier=Digi-Key; ComponentLink3URL: https://datasheet.ciiva.com/2043/cd00001884-2043961.pdf?src-supplier=Digi-Key; ComponentLink4Description: https://datasheet.ciiva.com/2043/cd00001884-2043960.pdf?src-supplier=Digi-Key; ComponentLink4URL: https://datasheet.ciiva.com/2043/cd00001884-2043960.pdf?src-supplier=Digi-Key; ComponentLink5Description: https://datasheet.ciiva.com/2043/cd00001884-2043962.pdf?src-supplier=Digi-Key; ComponentLink5URL: https://datasheet.ciiva.com/2043/cd00001884-2043962.pdf?src-supplier=Digi-Key; ComponentLink6Description: https://datasheet.ciiva.com/3225/cd00001884-3225081.pdf?src-supplier=Avnet; ComponentLink6URL: https://datasheet.ciiva.com/3225/cd00001884-3225081.pdf?src-supplier=Avnet; ComponentLink7Description: https://datasheet.ciiva.com/16496/cd00001884-16496030.pdf?src-supplier=Future+Electronics; ComponentLink7URL: https://datasheet.ciiva.com/16496/cd00001884-16496030.pdf?src-supplier=Future+Electronics; Height: 2.4mm; Lead Free: Lead Free; Length: 6.6mm; Library Name: ic-power.IntLib; Manufacturer Part Number: LD1086DT33TR; Max Operating Temperature: 125°C; Max Output Current: 1.5A; Min Operating Temperature: -40°C; Min Output Voltage: 3.3V; Mount: Surface Mount; Nominal Output Voltage: 3.3V; Number of Pins: 3; Number of Regulators: 1; Output Current: 1.5A; Output Voltage: 3.3V; Output Voltage Accuracy: 1%; Package Quantity: 1; Packaging: Cut Tape; Pricing 1: 5=1,49000, 50=1,32000, 100=1,14000, 500=1,01000 (EUR); Radiation Hardening: No; Reach SVHC Compliant: Yes; RoHS: Yes; RoHS Compliant: Yes; Series: -; Stock 1: 16461; Supplier 1: Farnell; Supplier Part Number 1: 1467774; Weight: 0.01lb; Width: 6.2mm
U6 = USBLC6-2SC6: TVS DIODE 5.25VWM 17VC SOT23-6; Manufacturer: STMicroelectronics; Breakdown Voltage: 6V; Capacitance: 3.5pF; Clamping Voltage: 17V; Data Rate: 480Mbps; Direction: Unidirectional,Bidirectional; Element Configuration: Dual; ESD Protection: Yes; Leakage Current: 150nA; Number of Bidirectional Channels: 2; Number of Elements: 1; Operating Supply Voltage: 1.1V; Peak Pulse Current: 5A; Reverse Standoff Voltage: 5.25V; Test Current: 1mA; Working Voltage: 5V; Case/Package: SOT-23; Category: TVS Diodes; Clamping Voltage-Max: 17V; Component Kind: Standard; ComponentLink1Description: https://datasheet.ciiva.com/1268/1690354-1268384.pdf?src-supplier=Element14; ComponentLink1URL: https://datasheet.ciiva.com/1268/1690354-1268384.pdf?src-supplier=Element14; ComponentLink2Description: https://datasheet.ciiva.com/4508/cd00050750-113117-4508433.pdf?src-supplier=Mouser; ComponentLink2URL: https://datasheet.ciiva.com/4508/cd00050750-113117-4508433.pdf?src-supplier=Mouser; ComponentLink3Description: https://datasheet.ciiva.com/15143/getdatasheetpartid-148465-15143789.pdf?src-supplier=Verical; ComponentLink3URL: https://datasheet.ciiva.com/15143/getdatasheetpartid-148465-15143789.pdf?src-supplier=Verical; ComponentLink4Description: https://datasheet.ciiva.com/16200/cd00050750-16200026.pdf?src-supplier=Future+Electronics; ComponentLink4URL: https://datasheet.ciiva.com/16200/cd00050750-16200026.pdf?src-supplier=Future+Electronics; ComponentLink5Description: https://datasheet.ciiva.com/41444/001184109-da-01-en-ic-esd-protecti-usblc6-2sc6-sot-23-6-stm-41444156.pdf?src-supplier=Conrad; ComponentLink5URL: https://datasheet.ciiva.com/41444/001184109-da-01-en-ic-esd-protecti-usblc6-2sc6-sot-23-6-stm-41444156.pdf?src-supplier=Conrad; Contact Plating: Tin,Matte; Depth: 1.75mm; Height: 1.3mm; Lead Free: Lead Free; Length: 3.05mm; Library Name: ic-power.IntLib; Manufacturer Part Number: USBLC6-2SC6; Max Breakdown Voltage: 6V; Max Operating Temperature: 125°C; Max Reverse Leakage Current: 150nA; Min Breakdown Voltage: 6V; Min Operating Temperature: -40°C; Mount: Surface Mount; Number of Channels: 2; Number of Pins: 6; Package Quantity: 3000; Packaging: Tape and Reel; Polarity: Unidirectional,Bidirectional; Power Line Protection: Yes; Pricing 1: 1=0,62000, 10=0,52700, 100=0,39340, 500=0,30908, 1000=0,23883 (USD); Radiation Hardening: No; Reach SVHC Compliant: Yes; Reverse Breakdown Voltage: 6V; RoHS: Yes; RoHS Compliant: Yes; Series: USB; Stock 1: 157523; Supplier 1: Digi-Key; Supplier Part Number 1: 497-5235-1-ND; Termination: SMD/SMT; Width: 1.75mm
U7 = NEO-7M-0-000: Rf Rx Glonass/gnss/gps 1.575GHZ; Manufacturer: u-blox; Sensitivity: -161dBm; Interface: I2C,SPI,USB,UART; Case/Package: SMD/SMT; Category: RF Receivers, Transceivers; Component Kind: Standard; ComponentLink1Description: https://www.u-blox.com/sites/default/files/products/documents/NEO-7_DataSheet_%28UBX-13003830%29.pdf?src-supplier=Digi-Key; ComponentLink1URL: https://www.u-blox.com/sites/default/files/products/documents/NEO-7_DataSheet_%28UBX-13003830%29.pdf?src-supplier=Digi-Key; Frequency: 1.575GHz; Library Name: ic-sensor.IntLib; Manufacturer Part Number: NEO-7M-0; Max Operating Temperature: 85°C; Min Operating Temperature: -40°C; Packaging: Tape and Reel; Pricing 1: 1=31,00000, 10=30,95000, 50=25,39000, 100=20,30010 (USD); RoHS: Yes; Stock 1: 750; Supplier 1: Digi-Key; Supplier Part Number 1: 672-NEO-7M-0CT-ND
U8 = 24AA32AT-I/ST IC EEPROM 32KBIT I2C: I²C™ Serial EEPROM, 1.7 to 5.5V, 400 kHz, -40 to 85°C, TSSOP-8, RoHS; Manufacturer: Microchip; Access Time: 900ns; Access Time-Max: 3500ns; Density: 32Kb; Frequency: 100kHz; Max Frequency: 400kHz; Min Supply Voltage: 1.8V; Nominal Supply Current: 3mA; Organization: 4KX8; Write Cycle Time-Max (tWC): 5ms; Write Protection: YES; Interface: I2C,Serial; Case/Package: TSSOP; Category: EEPROM; Component Kind: Standard; ComponentLink1Description: https://datasheet.ciiva.com/3186/21713l-3186182.pdf?src-supplier=Avnet; ComponentLink1URL: https://datasheet.ciiva.com/3186/21713l-3186182.pdf?src-supplier=Avnet; ComponentLink2Description: https://datasheet.ciiva.com/16309/filehandler-aspxddocname-en011853-16309708.pdf?src-supplier=Future+Electronics; ComponentLink2URL: https://datasheet.ciiva.com/16309/filehandler-aspxddocname-en011853-16309708.pdf?src-supplier=Future+Electronics; Contact Plating: Tin,Matte; Lead Free: Lead Free; Library Name: ic-interface.IntLib; Manufacturer Part Number: 24AA32AT-I/ST; Max Operating Temperature: 85°C; Max Supply Voltage: 5.5V; Memory Size: 32Kb; Memory Type: EEPROM; Min Operating Temperature: -40°C; Mount: Surface Mount; Number of Pins: 8; Package Quantity: 2500; Packaging: Tape and Reel; Pricing 1: 1=0,51000 (USD); Radiation Hardening: No; RoHS: Yes; RoHS Compliant: Yes; Stock 1: 1081; Supplier 1: Digi-Key; Supplier Part Number 1: 24AA32AT-I/STCT-ND; Supply Current: 3mA; Supply Voltage-Nom: 5.5V
U9 = MAX2659: IC AMP LOW NOISE 6-UDFN; Manufacturer: Maxim; Manufacturer Part Number: MAX2659ELT+T; Frequency: 1.57542GHz; Gain: 20.5dB; Max Frequency: 1.75GHz; Max Power Dissipation: 167mW; Max Supply Voltage: 3.6V; Min Supply Voltage: 1.6V; Noise Figure: 0.8dB; Number of Channels: 1; Operating Frequency: 1 GHz to 2 GHz; Operating Supply Current: 4.1mA; Operating Supply Voltage: 2.85V; P1dB: -12dBm; Amplifier Type: LOW NOISE; Case/Package: WFDFN; Category: RF Amplifiers; Component Kind: Standard; ComponentLink1Description: https://datasheet.ciiva.com/4915/max2659-74449-4915373.pdf?src-supplier=Mouser; ComponentLink1URL: https://datasheet.ciiva.com/4915/max2659-74449-4915373.pdf?src-supplier=Mouser; ComponentLink2Description: https://datasheet.ciiva.com/3301/max2659-3301469.pdf?src-supplier=Avnet; ComponentLink2URL: https://datasheet.ciiva.com/3301/max2659-3301469.pdf?src-supplier=Avnet; ComponentLink3Description: https://datasheet.ciiva.com/2790/max2659-2790456.pdf?src-supplier=Digi-Key; ComponentLink3URL: https://datasheet.ciiva.com/2790/max2659-2790456.pdf?src-supplier=Digi-Key; ComponentLink4Description: https://datasheet.ciiva.com/2790/max2659-2790457.pdf?src-supplier=Digi-Key; ComponentLink4URL: https://datasheet.ciiva.com/2790/max2659-2790457.pdf?src-supplier=Digi-Key; ComponentLink5Description: https://datasheet.ciiva.com/2790/max2659-2790455.pdf?src-supplier=Digi-Key; ComponentLink5URL: https://datasheet.ciiva.com/2790/max2659-2790455.pdf?src-supplier=Digi-Key; Height: 0.75mm; Lead Free: Lead Free; Length: 1.55mm; Library Name: ic-power.IntLib; Max Operating Temperature: 85°C; Min Operating Temperature: -40°C; Mount: Surface Mount; Number of Pins: 6; Package Quantity: 2500; Packaging: Tape and Reel; Pricing 1: 1=1,95000, 10=1,76000, 25=1,66000, 100=1,42000, 250=1,33000, 500=1,17000, 2500=1,07000, 5000=0,96100 (EUR); RoHS: Yes; RoHS Compliant: Yes; Series: -; Stock 1: 3223; Supplier 1: Farnell; Supplier Part Number 1: 2800966; Test Frequency: 1.57542GHz; Width: 1.05mm
U10 = ESP32-WROOM-32E (4MB): Manufacturer: Espressif Systems; Component Kind: Standard; ComponentLink1Description: Datasheet; ComponentLink1URL: https://www.espressif.com/sites/default/files/documentation/esp32-wroom-32e_esp32-wroom-32ue_datasheet_en.pdf; Library Name: ic-mcu.IntLib; Manufacturer Part Number: ESP32-WROOM-32E(4MB); Max Operating Temperature: 85°C; Min Operating Temperature: -40°C; RoHS: No; Supplier 1: Digi-Key; Supplier Part Number 1: 1965-ESP32-WROOM-32E(4MB)CT-ND
U11 = CP2102-GMR: IC USB-TO-UART BRIDGE 28VQFN; Manufacturer: Silicon Labs; Data Rate: 1000Kbps; Max Supply Voltage: 3.6V; Min Supply Voltage: 3V; Nominal Supply Current: 26mA; Number of Channels: 1; Operating Supply Current: 20mA; Interface: UART; Case/Package: QFN; Category: Interface ICs; Component Kind: Standard; ComponentLink1Description: https://datasheet.ciiva.com/6636/cp2102-9-6636566.pdf?src-supplier=Digi-Key; ComponentLink1URL: https://datasheet.ciiva.com/6636/cp2102-9-6636566.pdf?src-supplier=Digi-Key; ComponentLink2Description: https://datasheet.ciiva.com/6636/cp2102-9-6636571.pdf?src-supplier=Digi-Key; ComponentLink2URL: https://datasheet.ciiva.com/6636/cp2102-9-6636571.pdf?src-supplier=Digi-Key; Contact Plating: Tin; Lead Free: Lead Free; Library Name: ic-interface.IntLib; Manufacturer Part Number: CP2102-GMR; Max Operating Temperature: 85°C; Min Operating Temperature: -40°C; Mount: Surface Mount; Number of Pins: 28; Package Quantity: 1500; Packaging: Tape and Reel; Pricing 1: 1=5,06000 (USD); Radiation Hardening: No; RoHS: Yes; RoHS Compliant: Yes; Series: -; Stock 1: 117622; Supplier 1: Digi-Key; Supplier Part Number 1: 336-1160-1-ND; Supply Current: 26mA; Weight: 0.00239oz
J3 J4 = 2*1 JST: Component Kind: Standard; Library Name: cons.IntLib
J5 = MICRO USB 2.0 B TYPE: Component Kind: Standard; Library Name: cons.IntLib
J6 = 3*1 Header: Component Kind: Standard; Library Name: cons.IntLib
J7 J8 = SWITCH TACT BUTTON 6x6MM: Component Kind: Standard; Library Name: cons.IntLib
